# Altium schematic sheet Battery Charger.SchDoc("Battery Charger")
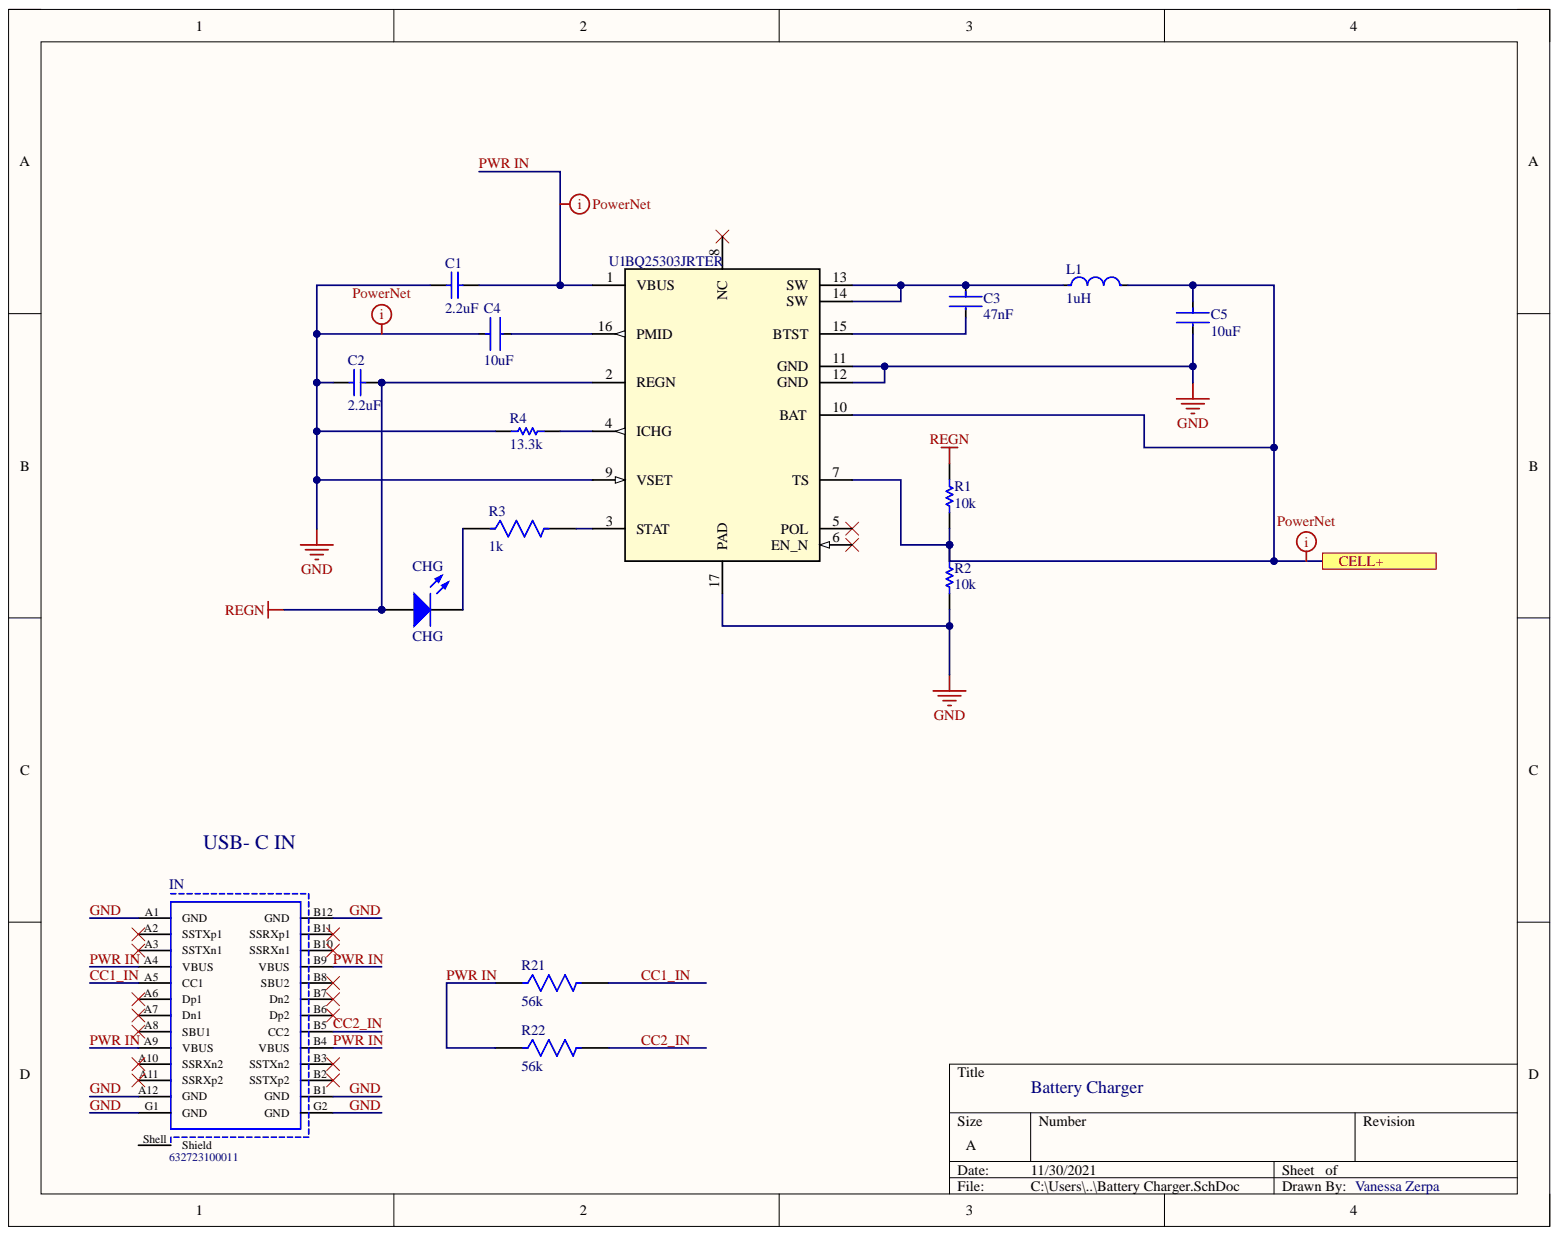
[diagram p0: Battery Charger.SchDoc]

## Parts
C1  2.2uF  [CAPC2013X152X50ML20T25]
C2  2.2uF  [CAPC2013X152X50ML20T25]
C3  47nF  [FP-C0805C-DN-MFG]
C4  10uF  [FP-C0805C-DG-MFG]
C5  10uF  [FP-C0805C-DG-MFG]
CHG  CHG  [0805_A]
IN  632723100011  [632723100011]
L1  1uH  [FP-LQM21DN_00-MFG]
R1  10k  [RESC2013X60X35NL10T20]
R2  10k  [RESC2013X60X35NL10T20]
R3  1k  [FP-CRCW0805-HPe3-IPC_A]
R4  13.3k  [RESC2013X70X40LL20T20]
R21  56k  [FP-CRCW0805-e3-IPC_B]
R22  56k  [FP-CRCW0805-e3-IPC_B]
U1  BQ25303JRTER  [RTE0016C]

## Ports
CELL+

## Nets
REGN: C2.2 CHG.2 R1.2 U1.2(REGN)
CC1_IN: IN.A5(CC1) R21.2
CC2_IN: IN.B5(CC2) R22.2
CELL+: C5.1 L1.2 R1.1 R2.2 U1.7(TS) U1.10(BAT) ; port CELL+
GND: C1.1 C2.1 C4.1 C5.2 IN.A1(GND) IN.A12(GND) IN.B1(GND) IN.B12(GND) IN.G1(GND) IN.G2(GND) R2.1 R4.1 U1.9(VSET) U1.11(GND) U1.12(GND) U1.17(PAD)
PWR IN: C1.2 IN.A4(VBUS) IN.A9(VBUS) IN.B4(VBUS) IN.B9(VBUS) R21.1 R22.1 U1.1(VBUS)
NetC3_1: C3.1 L1.1 U1.13(SW) U1.14(SW)
NetC3_2: C3.2 U1.15(BTST)
NetC4_2: C4.2 U1.16(PMID)
NetCHG_1: CHG.1 R3.1
NetR3_2: R3.2 U1.3(STAT)
NetR4_2: R4.2 U1.4(ICHG)

Not connected: IN A2(SSTXp1) A3(SSTXn1) A6(Dp1) A7(Dn1) A8(SBU1) A10(SSRXn2) A11(SSRXp2) B2(SSTXp2) B3(SSTXn2) B6(Dp2) B7(Dn2) B8(SBU2) B10(SSRXn1) B11(SSRXp1) Shell(Shield); U1 5(POL) 6(EN_N) 8(NC)

## Library data of the parts
C1 C2 = CMP-2007-04514-1: Manufacturer: AVX Interconnect / Elco; Part Number: 08053C225KAT2A; Component Kind: Standard; Library Name: Altium Content Vault
C3 = CMP-2007-03315-2: CAP CER 0.047UF 25V 5% NP0 0805; Manufacturer: KEMET; Manufacturer Part Number: C0805C473J3GACTU; Capacitance: 47,000 pF; Material: Ceramic; Temperature Characteristic: C0G; Temperature Coefficient: 30ppm/°C; Tolerance: 0.05; Value: 47,000 pF; Automotive: No; Category: Cap; Component Kind: Standard; ComponentLink2Description: Datasheet; ComponentLink2URL: https://content.kemet.com/datasheets/KEM_C1003_C0G_SMD.pdf; Device Class L1: Passive Components; Device Class L2: Capacitors; Device Class L3: Ceramic Capacitors; Digikey Description: CAP CER 0.047UF 25V 5% NP0 0805; Height: 0.88mm; Ipc Land Pattern Name: CAPC200125X78; Lead Free: Yes; Library Name: Altium Content Vault; Mouser Description: Multilayer Ceramic Capacitors MLCC - SMD/SMT 25volts 0.047uF 5% C0G; Octopart: https://octopart.com/c0805c473j3gactu-kemet-9563153; Package: C0805C-DN; RoHS: TRUE; Temperature: -55°C to +125°C; Temperature Range High: +125°C; Temperature Range Low: -55°C; Voltage Rating: 25 V
C4 C5 = CMP-2007-03243-2: CAP CER 10UF 10V X5R 0805; 3DS Part Number: 220-00001; Manufacturer: Kemet; Manufacturer Part Number: C0805C106K8PACTU; Material: Ceramic; Temperature Coefficient: 15%; Tolerance: 10%; Automotive: No; Capacitance: 10uF; Category: Cap; Component Kind: Standard; ComponentLink2Description: Datasheet; ComponentLink2URL: https://content.kemet.com/datasheets/KEM_C1006_X5R_SMD.pdf; Device Class L1: Passive Components; Device Class L2: Capacitors; Device Class L3: Ceramic Capacitors; Digikey Description: CAP CER 10UF 10V X5R 0805; Height: 1.4mm; Ipc Land Pattern Name: CAPC200125X125; Lead Free: Yes; Library Name: Altium Content Vault; Mouser Description: CAP CER 10UF 10V X5R 0805; Octopart: https://octopart.com/c0805c106k8pactu-kemet-98697; Package: C0805C-DG; RoHS: TRUE; Temperature: -55°C to +85°C; Temperature Characteristic: X5R; Temperature Range High: +85°C; Temperature Range Low: -55°C; Value: 10uF; Voltage: 10V; Voltage Rating: 10V
CHG = CMP-1426-00011-1: SMD mono-color Chip LED, WL-SMCW, Red; Manufacturer: Wuerth Elektronik; PartNumber: 150080RS75000; Color: Red; Component Kind: Standard; ComponentLink1Description: Manufacturer URL; ComponentLink1URL: http://www.we-online.com; ComponentLink2Description: Datasheet; ComponentLink2URL: http://katalog.we-online.com/led/datasheet/150080RS75000.pdf; Library Name: Altium Content Vault; PackageReference: 0805_A
IN = CMP-1502-03213-1: USB 3.1 Type C Receptacle WR-COM, Horizontal, SMT / THT; Manufacturer: Wurth Elektronik; Contact Resistance: 30mOhm; Rated Current: 5A; Working Voltage: 20VDC; Component Kind: Standard; Connector Type: USB3.1TypeC; Datasheet URL: https://katalog.we-online.de/em/datasheet/632723x00011%282%29.pdf; Gender: Receptacle; Library Name: Altium Content Vault; Manufacturer URL: http://www.we-online.de; Mounting Technology: SMT/THT; Operating Temperature: -40to105degC; Orientation: Horizontal; Package Reference: 632723100011; Packaging: TapeandReel; Part Number: 632723100011; Publisher: WurthElektronik
L1 = CMP-06042-012978-1: Multilayer Type Inductor for General Circuits, 0805 (2012), 1μH, 10%, 0.4Ω, 50mA; Core Material: Ferrite; DC Resistance (DCR): 400mR; Q Factor: 45; Self Resonant Frequency: 107MHz; Shielding: Shielded; Test Frequency: 10MHz; Case Code (Imperial): 0805; Case Code (Metric): 2012; Case/Package: 0805; Component Kind: Standard; Current Rating: 50mA; DC Current: 50mA; Depth: 1.45mm; Height: 0.85mm; Height - Seated (Max): 0.041inch; Inductance: 1uH; Inductance Tolerance: 10%; Lead Free: Lead Free; Length: 2mm; Library Name: Altium Content Vault; Max DC Current: 50mA; Max Operating Temperature: 85°C; Military Standard: Not; Min Operating Temperature: -40°C; Mount: Surface Mount; Package Quantity: 1; Packaging: Tape and Reel; Radiation Hardening: No; REACH SVHC: No SVHC; Termination: SMD/SMT; Tolerance: 10%; Width: 1.25mm
R1 R2 = CMP-1659-00013-4: Chip Resistor, 10 KOhm, +/- 1%, 0.125 W, -55 to 155 degC, 0805 (2012 Metric), RoHS, Tape and Reel; Manufacturer: Yageo; Voltage Rating: 150 V; Component Kind: Standard; ComponentLink1Description: Manufacturer URL; ComponentLink1URL: http://www.yageo.com/; ComponentLink2Description: Datasheet; ComponentLink2URL: http://www.yageo.com/documents/recent/PYu-RC_Group_51_RoHS_L_04.pdf; DatasheetVersion: Rev. 4, 02/2016; Height: 0.5 mm; Length: 2 mm; Library Name: Altium Content Vault; Max Operating Temperature: 155 degC; Min Operating Temperature: -55 degC; Mount: Surface Mount; Mounting Technology: Surface Mount; Package Quantity: 5000; PackageDescription: 2-Pin Surface Mounted Device, Body 2 x 1.25 mm; PackageReference: 0805; Packaging: Tape and Reel; Pins: 2; REACH SVHC: No SVHC; RoHSCompliant: true
R3 = CMP-02407-004529-1: RES Thick Film, 1kΩ, 1%, 0.5W, 100ppm/°C, 0805; Power Rating: 330mW; Resistance: 1kR; Voltage Rating: 150V; Case Code (Imperial): 0805; Case Code (Metric): 2012; Case/Package: 0805; Component Kind: Standard; Composition: Thick Film; Contact Plating: Tin; Depth: 1.25mm; Height: 0.5mm; Lead Free: Lead Free; Length: 2mm; Library Name: Altium Content Vault; Max Operating Temperature: 155°C; Military Standard: Not; Min Operating Temperature: -55°C; Mount: Surface Mount; Number of Pins: 2; Package Quantity: 5000; Packaging: Tape and Reel; Radiation Hardening: No; Temperature Coefficient: 100ppm/°C; Termination: Solder; Tolerance: 1%; Voltage Rating (DC): 150V; Weight: 0.000194oz; Width: 1.2mm
R4 = CMP-2001-04421-1: Manufacturer: Panasonic; Part Number: ERJ-6ENF1332V; Component Kind: Standard; Library Name: Altium Content Vault
R21 R22 = CMP-2001-04015-2: RES Thick Film, 56kΩ, 1%, 0.125W, 100ppm/°C, 0805; Max Power Dissipation: 125mW; Resistance: 56kR; Voltage Rating: 150V; Case Code (Imperial): 0805; Case Code (Metric): 2012; Case/Package: 0805; Component Kind: Standard; Composition: Thick Film; Contact Plating: Tin; Depth: 1.25mm; Features: Automotive AEC-Q200; Height: 0.45mm; Lead Free: Lead Free; Length: 2mm; Library Name: Altium Content Vault; Max Operating Temperature: 155°C; Military Standard: Not; Min Operating Temperature: -55°C; Mount: Surface Mount; Number of Pins: 2; Number of Terminations: 2; Package Quantity: 10; Packaging: Tape and Reel; Power Rating: 125mW; Radiation Hardening: No; Temperature Coefficient: 100ppm/°C; Termination: SMD/SMT; Tolerance: 1%; Voltage Rating (DC): 150V; Weight: 0.000194oz; Width: 1.25mm
U1 = BQ25303JRTER: Standalone 1-cell 17-V, 3.0-A battery charger with JEITA battery temperature monitoring 16-WQFN -40 to 85; Manufacturer: Texas Instruments; Category: Integrated Circuits (ICs); Component Kind: Standard; Library Name: BatteryCharger.SchLib; Manufacturer Part Number: BQ25303JRTER; Manufacturer_Part_Number: BQ25303JRTER; Mfr_Name: Texas Instruments; Pricing 1: 1=2.18000, 10=1.97000, 25=1.86000, 50=1.86000, 100=1.59000, 250=1.49000, 500=1.30000, 1000=1.08000, 3000=1.07000, 10000=1.07000 (USD); RefDes: RefDes; RoHS: Yes; Stock 1: 48; Supplier 1: Mouser; Supplier Part Number 1: 595-BQ25303JRTER; Type: DEV; Copyright: Copyright (C) 2021 Ultra Librarian. All rights reserved.
